# Altium schematic sheet RPI-TPS-GK - CPLD-XC95144.SchDoc
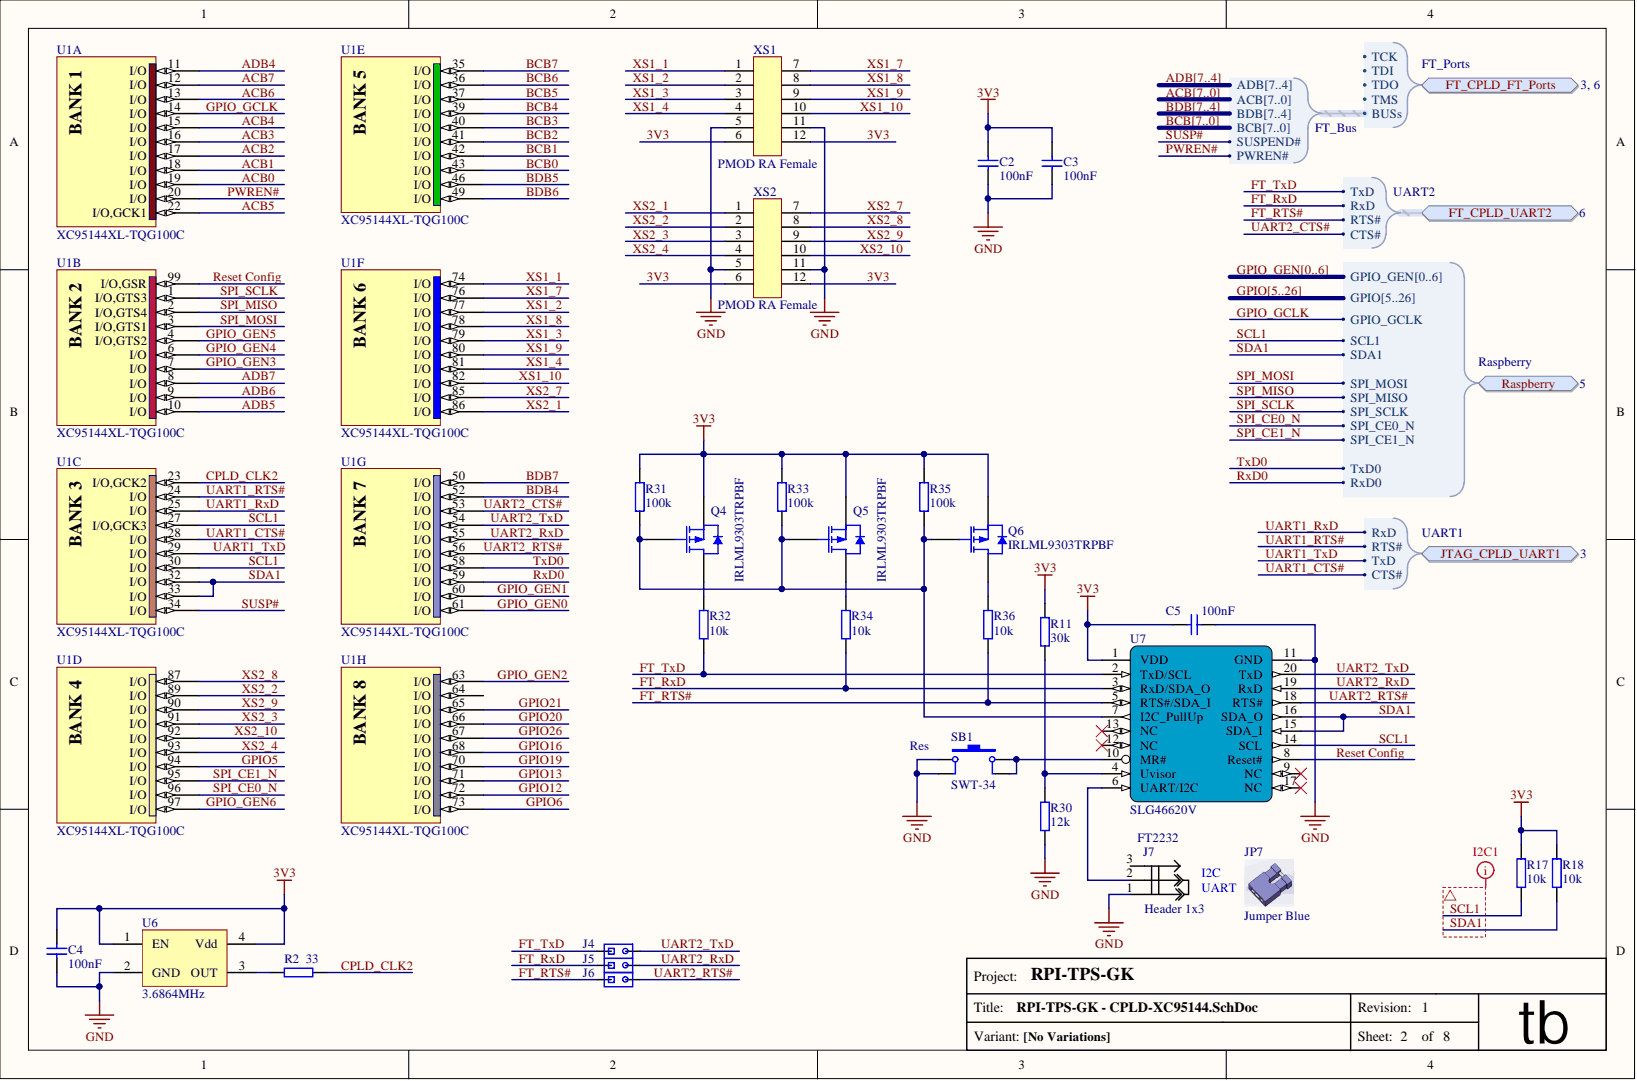
[diagram p0: RPI-TPS-GK - CPLD-XC95144.SchDoc]

## Parts
C2  value 100nF  [C0603]  (hidden comment: CAP)
C3  value 100nF  [C0603]  (hidden comment: CAP)
C4  value 100nF  [C0603]  (hidden comment: CAP)
C5  value 100nF  [C0603]  (hidden comment: CAP)
J4  (no value)  [Jumper_smd_d3]  (no BOM)
J5  (no value)  [Jumper_smd_d3]  (no BOM)
J6  (no value)  [Jumper_smd_d3]  (no BOM)
J7  FT2232  value Header 1x3  [Jumper_3pin]
JP7  value Jumper Blue  Supplier 1 Part: Jumper  (hidden comment: VCC_sel)  (mechanical)
Q4  IRLML9303TRPBF  [SOT-23]
Q5  IRLML9303TRPBF  [SOT-23]
Q6  IRLML9303TRPBF  [SOT-23]
R2  value 33  [0603_RESISTOR]  (hidden comment: Resistor)
R11  value 30k  [0603_RESISTOR]  (hidden comment: Resistor)
R17  value 10k  [0603_RESISTOR]  (hidden comment: Resistor)
R18  value 10k  [0603_RESISTOR]  (hidden comment: Resistor)
R30  value 12k  [0603_RESISTOR]  (hidden comment: Resistor)
R31  value 100k  [0603_RESISTOR]  (hidden comment: Resistor)
R32  value 10k  [0603_RESISTOR]  (hidden comment: Resistor)
R33  value 100k  [0603_RESISTOR]  (hidden comment: Resistor)
R34  value 10k  [0603_RESISTOR]  (hidden comment: Resistor)
R35  value 100k  [0603_RESISTOR]  (hidden comment: Resistor)
R36  value 10k  [0603_RESISTOR]  (hidden comment: Resistor)
SB1  Res  value SWT-34  [Key 6.2x6.2]
U1  value XC95144XL-TQG100C  Supplier 1 Part: XC95144XL-TQG100C  [TQ100 - XC95144XL]  (hidden comment: XC95144XL-SQ100)  (parts A,B,C,D,E,F,G,H)
U6  value 3.6864MHz  Manufacturer Part: 3.6864MHz  [OSC_5x7]  (hidden comment: OSC_Crystal Oscillator)
U7  SLG46620V  [STQFN-20]
XS1  value PMOD RA Female  [CONN_2DG_H_F]  (hidden comment: DG2_connector_horizontal_f)
XS2  value PMOD RA Female  [CONN_2DG_H_F]  (hidden comment: DG2_connector_horizontal_f)

## Ports
FT_CPLD_FT_Ports, FT_CPLD_FT_PORTS.BUSS.ACB0, FT_CPLD_FT_PORTS.BUSS.ACB1, FT_CPLD_FT_PORTS.BUSS.ACB2, FT_CPLD_FT_PORTS.BUSS.ACB3, FT_CPLD_FT_PORTS.BUSS.ACB4, FT_CPLD_FT_PORTS.BUSS.ACB5, FT_CPLD_FT_PORTS.BUSS.ACB6, FT_CPLD_FT_PORTS.BUSS.ACB7, FT_CPLD_FT_Ports.BUSs.ACB[7..0], FT_CPLD_FT_PORTS.BUSS.ADB4, FT_CPLD_FT_PORTS.BUSS.ADB5, FT_CPLD_FT_PORTS.BUSS.ADB6, FT_CPLD_FT_PORTS.BUSS.ADB7, FT_CPLD_FT_Ports.BUSs.ADB[7..4], FT_CPLD_FT_PORTS.BUSS.BCB0, FT_CPLD_FT_PORTS.BUSS.BCB1, FT_CPLD_FT_PORTS.BUSS.BCB2, FT_CPLD_FT_PORTS.BUSS.BCB3, FT_CPLD_FT_PORTS.BUSS.BCB4, FT_CPLD_FT_PORTS.BUSS.BCB5, FT_CPLD_FT_PORTS.BUSS.BCB6, FT_CPLD_FT_PORTS.BUSS.BCB7, FT_CPLD_FT_Ports.BUSs.BCB[7..0], FT_CPLD_FT_PORTS.BUSS.BDB4, FT_CPLD_FT_PORTS.BUSS.BDB5, FT_CPLD_FT_PORTS.BUSS.BDB6, FT_CPLD_FT_PORTS.BUSS.BDB7, FT_CPLD_FT_Ports.BUSs.BDB[7..4], FT_CPLD_FT_Ports.BUSs.PWREN#, FT_CPLD_FT_Ports.BUSs.SUSPEND#, FT_CPLD_FT_Ports.TCK, FT_CPLD_FT_Ports.TDI, FT_CPLD_FT_Ports.TDO, FT_CPLD_FT_Ports.TMS, FT_CPLD_UART2, FT_CPLD_UART2.CTS#, FT_CPLD_UART2.RTS#, FT_CPLD_UART2.RxD, FT_CPLD_UART2.TxD, JTAG_CPLD_UART1, JTAG_CPLD_UART1.CTS#, JTAG_CPLD_UART1.RTS#, JTAG_CPLD_UART1.RxD, JTAG_CPLD_UART1.TxD, Raspberry, RASPBERRY.GPIO5, RASPBERRY.GPIO6, RASPBERRY.GPIO7, RASPBERRY.GPIO8, RASPBERRY.GPIO9, RASPBERRY.GPIO10, RASPBERRY.GPIO11, RASPBERRY.GPIO12, RASPBERRY.GPIO13, RASPBERRY.GPIO14, RASPBERRY.GPIO15, RASPBERRY.GPIO16, RASPBERRY.GPIO17, RASPBERRY.GPIO18, RASPBERRY.GPIO19, RASPBERRY.GPIO20, RASPBERRY.GPIO21, RASPBERRY.GPIO22, RASPBERRY.GPIO23, RASPBERRY.GPIO24, RASPBERRY.GPIO25, RASPBERRY.GPIO26, Raspberry.GPIO[5..26], Raspberry.GPIO_GCLK, RASPBERRY.GPIO_GEN0, RASPBERRY.GPIO_GEN1, RASPBERRY.GPIO_GEN2, RASPBERRY.GPIO_GEN3, RASPBERRY.GPIO_GEN4, RASPBERRY.GPIO_GEN5, RASPBERRY.GPIO_GEN6, Raspberry.GPIO_GEN[0..6], Raspberry.RxD0, Raspberry.SCL1, Raspberry.SDA1, Raspberry.SPI_CE0_N, Raspberry.SPI_CE1_N, Raspberry.SPI_MISO, Raspberry.SPI_MOSI, Raspberry.SPI_SCLK, Raspberry.TxD0

## Nets
GND: C2.1 C3.1 C4.1 C5.1 J7.1 R30.1 SB1.1 SB1.3 U6.2(GND) U7.11(GND) XS1.5 XS1.11 XS2.5 XS2.11
3V3: C2.2 C3.2 C4.2 C5.2 Q4.2 Q5.2 Q6.2 R11.1 R17.2 R18.2 R31.2 R33.2 R35.2 U6.1(EN) U6.4(Vdd) U7.1(VDD) XS1.6 XS1.12 XS2.6 XS2.12
ACB0: U1.19(I/O) ; port FT_CPLD_FT_Ports  [also labelled ACB]
ACB1: U1.18(I/O) ; port FT_CPLD_FT_Ports  [also labelled ACB]
ACB2: U1.17(I/O) ; port FT_CPLD_FT_Ports  [also labelled ACB]
ACB3: U1.16(I/O) ; port FT_CPLD_FT_Ports  [also labelled ACB]
ACB4: U1.15(I/O) ; port FT_CPLD_FT_Ports  [also labelled ACB]
ACB5: U1.22(I/O,GCK1) ; port FT_CPLD_FT_Ports  [also labelled ACB]
ACB6: U1.13(I/O) ; port FT_CPLD_FT_Ports  [also labelled ACB]
ACB7: U1.12(I/O) ; port FT_CPLD_FT_Ports  [also labelled ACB]
ADB4: U1.11(I/O) ; port FT_CPLD_FT_Ports  [also labelled ADB]
ADB5: U1.10(I/O) ; port FT_CPLD_FT_Ports  [also labelled ADB]
ADB6: U1.9(I/O) ; port FT_CPLD_FT_Ports  [also labelled ADB]
ADB7: U1.8(I/O) ; port FT_CPLD_FT_Ports  [also labelled ADB]
BCB0: U1.43(I/O) ; port FT_CPLD_FT_Ports  [also labelled BCB]
BCB1: U1.42(I/O) ; port FT_CPLD_FT_Ports  [also labelled BCB]
BCB2: U1.41(I/O) ; port FT_CPLD_FT_Ports  [also labelled BCB]
BCB3: U1.40(I/O) ; port FT_CPLD_FT_Ports  [also labelled BCB]
BCB4: U1.39(I/O) ; port FT_CPLD_FT_Ports  [also labelled BCB]
BCB5: U1.37(I/O) ; port FT_CPLD_FT_Ports  [also labelled BCB]
BCB6: U1.36(I/O) ; port FT_CPLD_FT_Ports  [also labelled BCB]
BCB7: U1.35(I/O) ; port FT_CPLD_FT_Ports  [also labelled BCB]
BDB4: U1.52(I/O) ; port FT_CPLD_FT_Ports  [also labelled BDB]
BDB5: U1.46(I/O) ; port FT_CPLD_FT_Ports  [also labelled BDB]
BDB6: U1.49(I/O) ; port FT_CPLD_FT_Ports  [also labelled BDB]
BDB7: U1.50(I/O) ; port FT_CPLD_FT_Ports  [also labelled BDB]
CPLD_CLK2: R2.2 U1.23(I/O,GCK2)
FT_RTS#: J6.1 R36.1 U7.5(RTS#/SDA_I) ; port FT_CPLD_UART2
FT_RxD: J5.1 R34.1 U7.3(RxD/SDA_O) ; port FT_CPLD_UART2
FT_TxD: J4.1 R32.1 U7.2(TxD/SCL) ; port FT_CPLD_UART2
GPIO5: U1.94(I/O) ; port Raspberry  [also labelled GPIO]
GPIO6: U1.73(I/O) ; port Raspberry  [also labelled GPIO]
GPIO12: U1.72(I/O) ; port Raspberry  [also labelled GPIO]
GPIO13: U1.71(I/O) ; port Raspberry  [also labelled GPIO]
GPIO16: U1.68(I/O) ; port Raspberry  [also labelled GPIO]
GPIO19: U1.70(I/O) ; port Raspberry  [also labelled GPIO]
GPIO20: U1.66(I/O) ; port Raspberry  [also labelled GPIO]
GPIO21: U1.65(I/O) ; port Raspberry  [also labelled GPIO]
GPIO26: U1.67(I/O) ; port Raspberry  [also labelled GPIO]
GPIO_GCLK: U1.14(I/O) ; port Raspberry
GPIO_GEN0: U1.61(I/O) ; port Raspberry  [also labelled GPIO_GEN]
GPIO_GEN1: U1.60(I/O) ; port Raspberry  [also labelled GPIO_GEN]
GPIO_GEN2: U1.63(I/O) ; port Raspberry  [also labelled GPIO_GEN]
GPIO_GEN3: U1.7(I/O) ; port Raspberry  [also labelled GPIO_GEN]
GPIO_GEN4: U1.6(I/O) ; port Raspberry  [also labelled GPIO_GEN]
GPIO_GEN5: U1.4(I/O,GTS2) ; port Raspberry  [also labelled GPIO_GEN]
GPIO_GEN6: U1.97(I/O) ; port Raspberry  [also labelled GPIO_GEN]
PWREN#: U1.20(I/O) ; port FT_CPLD_FT_Ports
Reset Config: U1.99(I/O,GSR) U7.8(Reset#)
RxD0: U1.59(I/O) ; port Raspberry
SCL1: R17.1 U1.27(I/O,GCK3) U1.30(I/O) U7.14(SCL) ; port Raspberry
SDA1: R18.1 U1.32(I/O) U1.33(I/O) U7.15(SDA_I) U7.16(SDA_O) ; port Raspberry
SPI_CE0_N: U1.96(I/O) ; port Raspberry
SPI_CE1_N: U1.95(I/O) ; port Raspberry
SPI_MISO: U1.2(I/O,GTS4) ; port Raspberry
SPI_MOSI: U1.3(I/O,GTS1) ; port Raspberry
SPI_SCLK: U1.1(I/O,GTS3) ; port Raspberry
SUSP#: U1.34(I/O) ; port FT_CPLD_FT_Ports
TxD0: U1.58(I/O) ; port Raspberry
UART1_CTS#: U1.28(I/O) ; port JTAG_CPLD_UART1
UART1_RTS#: U1.24(I/O) ; port JTAG_CPLD_UART1
UART1_RxD: U1.25(I/O) ; port JTAG_CPLD_UART1
UART1_TxD: U1.29(I/O) ; port JTAG_CPLD_UART1
UART2_CTS#: U1.53(I/O) ; port FT_CPLD_UART2
UART2_RTS#: J6.2 U1.56(I/O) U7.18(RTS#)
UART2_RxD: J5.2 U1.55(I/O) U7.19(RxD)
UART2_TxD: J4.2 U1.54(I/O) U7.20(TxD)
XS1_1: U1.74(I/O) XS1.1
XS1_2: U1.77(I/O) XS1.2
XS1_3: U1.79(I/O) XS1.3
XS1_4: U1.81(I/O) XS1.4
XS1_7: U1.76(I/O) XS1.7
XS1_8: U1.78(I/O) XS1.8
XS1_9: U1.80(I/O) XS1.9
XS1_10: U1.82(I/O) XS1.10
XS2_1: U1.86(I/O) XS2.1
XS2_2: U1.89(I/O) XS2.2
XS2_3: U1.91(I/O) XS2.3
XS2_4: U1.93(I/O) XS2.4
XS2_7: U1.85(I/O) XS2.7
XS2_8: U1.87(I/O) XS2.8
XS2_9: U1.90(I/O) XS2.9
XS2_10: U1.92(I/O) XS2.10
NetJ7_2: J7.2 U7.6(UART/I2C)
NetQ4_1: Q4.1 Q5.1 Q6.1 R31.1 R33.1 R35.1 U7.7(I2C_PullUp)
NetQ4_3: Q4.3 R32.2
NetQ5_3: Q5.3 R34.2
NetQ6_3: Q6.3 R36.2
NetR2_1: R2.1 U6.3(OUT)
NetR11_2: R11.2 R30.2 U7.4(Uvisor)
NetSB1_2: SB1.2 SB1.4 U7.10(MR#)
GPIO7: ; port Raspberry  [also labelled GPIO]
GPIO8: ; port Raspberry  [also labelled GPIO]
GPIO9: ; port Raspberry  [also labelled GPIO]
GPIO10: ; port Raspberry  [also labelled GPIO]
GPIO11: ; port Raspberry  [also labelled GPIO]
GPIO14: ; port Raspberry  [also labelled GPIO]
GPIO15: ; port Raspberry  [also labelled GPIO]
GPIO17: ; port Raspberry  [also labelled GPIO]
GPIO18: ; port Raspberry  [also labelled GPIO]
GPIO22: ; port Raspberry  [also labelled GPIO]
GPIO23: ; port Raspberry  [also labelled GPIO]
GPIO24: ; port Raspberry  [also labelled GPIO]
GPIO25: ; port Raspberry  [also labelled GPIO]
N00008: ; port FT_CPLD_FT_Ports
N00009: ; port FT_CPLD_FT_Ports
N00010:
N00011: ; port FT_CPLD_FT_Ports
N00012: ; port FT_CPLD_FT_Ports

Not connected: J7 3; U1 64(I/O); U7 9(NC) 12(NC) 13(NC) 17(NC)

## Library data of the parts
C2-C5 = CAP: Manufacturer: Vishay / Vitramon; Manufacturer Part: VJ0603Y104JXJPW1BC; Type of Component: Ceramic Capacitor; Component Kind: Standard; Manufacturer Link: http://www.vishay.com/product?docid=28548; Package / Case: 0603; Supplier 1: aliexpress.com; Supplier 1 Link: aliexpress.com/item/100pcs-lot-100nF-X7R-Error-10-50V-0603-smd-capacitor/32318089455.html?spm=2114.03010208.3.2.PDNk2A&ws_ab_test=searchweb201556_8,searchweb201602_1_10065_10068_112_10069_110_111_418_10017_109_108_10060_10061_10062_10057_10039_10056_10055_10054_10059_10058_10073_10070_10052_10053_10050_10051,searchweb201603_1&btsid=c39062ca-3db8-4562-8db0-c782461646c7; Supplier 2: http://imrad.com.ua/; Supplier 2 Link: http://imrad.com.ua/ru/cc0603krx7r8bb104; Supplier 2 Part: 182925; Supplier 3: http://voron.ua; Supplier 3 Link: http://voron.ua/catalog/001832; Supplier 3 Part: 001832; Library Name: RPI-VITA.IntLib
J4-J6 = jumper_2pin_pads: Library Name: RPI-VITA.IntLib
J7 = jumper_3pin: Type of Component: Header for jumper 3 pin; Component Kind: Standard; Package / Case: Header 1 x 3; Supplier 1: aliexpress.com; Supplier 1 Link: aliexpress.com/item/1Set-20PCS-40Pin-2-54mm-Single-Row-Straight-Male-Pin-Header-Connector-Strip-For-PBC-Ardunio/32329088739.html?spm=2114.03010208.3.2.0ijeiM&ws_ab_test=searchweb201556_1,searchweb201644_1_505_506_503_504_502_10001_10002_10017_10010_10005_10011_10006_10003_10004_10009_10007_10008,searchweb201560_5,searchweb1451318400_-1,searchweb1451318411_6452&btsid=d8fe2734-cbe4-429c-a307-e5400297e31b; Supplier 2: http://imrad.com.ua; Supplier 2 Link: http://imrad.com.ua/ru/pls-40-product-122266; Supplier 2 Part: 202826; Supplier 3: http://voron.ua; Supplier 3 Link: http://voron.ua/catalog/000631; Supplier 3 Part: 000631; Library Name: RPI-VITA.IntLib
JP7 = jumper blue: Manufacturer: Great Electric; Manufacturer Part: Jumper Cap Shunts Short Circuit Cap; Package / Case: 2.54mm; Supplier 1: aliexpress.com; Supplier 1 Link: http://www.aliexpress.com/item/500pcs-lot-Asssorted-Color-2-54mm-Standard-Circuit-Board-Jumper-Cap-Shunts-Short-Circuit-Cap-CGKCH119/32344305619.html?spm=2114.03010208.3.80.nPPmoy&ws_ab_test=searchweb201556_1,searchweb201644_1_505_506_503_504_502_10001_10002_10017_10010_10005_10011_10006_10003_10004_10009_10007_10008,searchweb201560_5,searchweb1451318400_-1,searchweb1451318411_6452&btsid=16d11777-edde-4755-b8b5-9adacb495b09; Type of Component: Jumper Blue; Library Name: RPI-VITA.IntLib
Q4-Q6 = p-channel MOSFET: Manufacturer: International Rectifier; Type of Component: Transistor; Component Kind: Standard; Description: p-channel MOSFET; Manufacturer Part: IRLML9303TRPBF; Package / Case: SOT-23; Supplier 2: http://imrad.com.ua; Supplier 2 Link: http://imrad.com.ua/ru/irlml9303trpbf; Supplier 2 Part: 165010; Supplier 3: http://voron.ua; Supplier 3 Link: http://voron.ua/catalog/024279; Supplier 3 Part: 024279; Value: IRLML9303TRPBF; Library Name: RPI-VITA.IntLib
R2 = Resistor: Manufacturer: Vishay / Dale; Manufacturer Part: CRCW060333R0FKEA; Component Kind: Standard; Manufacturer Link: http://www.vishay.com/product?docid=20035; Package / Case: 0603; Supplier 1: aliexpress.com; Supplier 1 Link: aliexpress.com/store/product/0603-SMD-Resistor-1-33-ohm-5000pcs-lot/506373_32308168229.html?detailNewVersion=&categoryId=400503&spm=2114.12010208.1000023.114.SHnexu; Supplier 1 Part: 0603 33; Supplier 2: http://imrad.com.ua; Supplier 2 Link: http://imrad.com.ua/ru/rc0603fr-0733rl; Supplier 2 Part: 183826; Supplier 3: http://voron.ua; Supplier 3 Link: http://voron.ua/catalog/023629; Supplier 3 Part: 023629; Type of Component: Resistor; Library Name: RPI-VITA.IntLib
R17 R18 R32 R34 R36 = Resistor: Manufacturer: Vishay / Dale; Manufacturer Part: CRCW060310K0FKEA; Component Kind: Standard; Manufacturer Link: http://www.vishay.com/product?docid=20035; Package / Case: 0603; Supplier 1: aliexpress.com; Supplier 1 Link: aliexpress.com/store/product/0603-SMD-Resistor-5-10K-ohm-5000pcs-lot/506373_32307351301.html?storeId=506373&spm=2114.12010208.100007.103.XAQnpm; Supplier 1 Part: 0603 10k; Supplier 2: http://imrad.com.ua/; Supplier 2 Link: http://imrad.com.ua/ru/rc0603jr-0710kl; Supplier 2 Part: 183440; Supplier 3: http://www.kosmodrom.com.ua; Supplier 3 Link: http://www.kosmodrom.com.ua/prodlist.php?page=0&name=%280603%29+10+kom&okbutton=%CF%EE%E8%F1%EA+%EF%EE+%F1%EA%EB%E0%E4%F3; Supplier 3 Part: (0603) 10 kom; Type of Component: Resistor; Library Name: RPI-VITA.IntLib
R30 = Resistor: Manufacturer: Vishay / Dale; Manufacturer Part: CRCW060312K0FKEA; Component Kind: Standard; Manufacturer Link: http://www.vishay.com/product?docid=20035; Package / Case: 0603; Supplier 1: aliexpress.com; Supplier 1 Link: aliexpress.com/item/Free-Shipping-100PCS-0603-12K-12K-OHM-5-smd-resistor/1871918358.html?spm=2114.01010208.3.43.AuqUxr&ws_ab_test=searchweb0_0,searchweb201602_1_10065_10068_10501_10503_10000032_119_10000025_10000029_430_10000028_10060_10062_10056_10055_10000062_10054_10059_10099_10000022_10000012_10103_10000015_10102_10096_10000018_10000019_10000056_10000059_10052_10053_10107_10050_10106_10051_10000053_10000007_10000050_10084_10118_10083_10000047_10080_10082_10081_10110_10111_10112_10113_10114_10115_10000041_10000044_10078_10079_10000038_429_10073_10000035_10121-10503_10501,searchweb201603_1,afswitch_1,single_sort_1_default&btsid=5caa854b-9869-4055-9d65-88f7445dd534; Supplier 1 Part: 0603 12k; Supplier 2: http://imrad.com.ua/; Supplier 2 Link: http://imrad.com.ua/ru/rc0603jr-0712kl; Supplier 2 Part: 194449; Supplier 3: http://voron.ua/; Supplier 3 Link: http://voron.ua/catalog/023603; Supplier 3 Part: 023603; Type of Component: Resistor; Library Name: RPI-VITA.IntLib
SB1 = SB_Tactile: Tactile Switches; Type of Component: Tact Switch; Component Kind: Standard; Package / Case: 6.2 x 6.2 x 5; Supplier 1: aliexpress.com; Supplier 1 Link: aliexpress.com/item/50-Pcs-Momentary-Tact-Tactile-Switch-SMD-SMT-PCB-4-Pin-6-x-6-x/32606731512.html?spm=2114.03010208.3.152.FgYqgH&ws_ab_test=searchweb0_0,searchweb201602_1_10065_10068_10501_10503_10000032_119_10000025_10000029_430_10000028_10060_10062_10056_10055_10000062_10054_10059_10099_10000022_10000012_10103_10000015_10102_10096_10000018_10000019_10000056_10000059_10052_10053_10107_10050_10106_10051_10000053_10000007_10000050_10117_10084_10083_10118_10000047_10080_10082_10081_10110_10111_10112_10113_10114_10115_10000041_10000044_10078_10079_10000038_429_10073_10000035_10121-10503_10501,searchweb201603_1,afswitch_1,single_sort_1_default&btsid=da13b4f2-05a9-443d-b1b0-b3b976e778d9; Supplier 2: http://imrad.com.ua/; Supplier 2 Link: http://imrad.com.ua/ru/swt-34-h5mm; Supplier 2 Part: 41517; Supplier 3: http://voron.ua/; Supplier 3 Link: http://voron.ua/catalog/017636; Supplier 3 Part: 017636; Library Name: RPI-VITA.IntLib
U1 = XC95144XL-SQ100: The XC95144XL is a 3.3V CPLD targeted for high-performance,  low-voltage applications in leading-edge communications  and computing systems.; Manufacturer: Xilinx Inc; Manufacturer Part: XC95144XL-10TQG100C; Component Kind: Standard; Manufacturer Link: http://xgoogle.xilinx.com/search?output=xml_no_dtd&ie=UTF-8&oe=UTF-8&client=xilinx&proxystylesheet=xilinx&site=EntireSite&filter=0&num=200&sort=date:D:L:d1&getfields=*&show_dynamic_navigation=1&requiredfields=-Archived%3Atrue&q=XC95144XL-10TQG100C&as_q=; Package / Case: TQG-100C; Supplier 1: HK-Ada; Type of Component: CPLD; Library Name: RPI-VITA.IntLib
U6 = OSC_Crystal Oscillator: Manufacturer: KKQ; Type of Component: Clock Oscillator; Component Kind: Standard; Package / Case: 5 mm x 7 mm; Supplier 1: aliexpress.com; Supplier 1 Link: http://aliexpress.com/item/100PCS-Original-NEW-3-6864MHZ-3-6864M-3-6864-5-7-4P-5070-OSC-5-00mm/32275012196.html?detailNewVersion=1; Supplier 1 Part: 3.6864MHz 4 pin; Library Name: RPI-VITA.IntLib
U7 = SLG46620: GreenPAK Programmable Mixed Signal Array; Manufacturer: Silego; Type of Component: GPAK-4; Component Kind: Standard; Manufacturer Link: http://www.silego.com/products/greenpak4.html; Manufacturer Part: SLG46620V; Package / Case: STQFN-20; Supplier 1: silego.com; Supplier 1 Link: http://www.silego.com/buy/index.php?main_page=product_info&cPath=67&products_id=379; Supplier 1 Part: SLG46620V; Value: SLG46620V; Library Name: RPI-VITA.IntLib
XS1 XS2 = DG2_connector_horizontal_f: SSHR-1-06-D; Manufacturer: HK-Ada; Manufacturer Part: PMOD 2x6 RA F; Type of Component: PMOD Right Angle Female; Component Kind: Standard; Package / Case: Header 2x6 RA; Supplier 1: aliexpress.com; Supplier 1 Link: aliexpress.com/item/100pcs-lot-2-54MM-2-6-P-2-54mm-2X6-Female-Double-Cab-Looper-Right-Angle/32674987997.html?spm=2114.03010208.3.2.JhMAJ9&ws_ab_test=searchweb0_0,searchweb201602_1_116_10065_117_10068_114_115_10069_113_10017_10080_10082_10081_10060_10061_10062_10039_10056_10055_10054_10059_10078_10079_10073_10070_421_420_10052_10053_10050_10051,searchweb201603_1&btsid=bf0396e8-448a-4b04-ba35-cc9eaa25fb0f; Supplier 1 Part: Header 2x6; Supplier 2: voron.ua; Supplier 2 Link: http://voron.ua/catalog/019905; Supplier 2 Part: 019905; Library Name: RPI-VITA.IntLib
R11 R31 R33 R35 = Resistor: Component Kind: Standard; Package / Case: 0603; Supplier 1: aliexpress.com; Type of Component: Resistor; Library Name: RPI-VITA.IntLib
  R11: Supplier 1 Link: aliexpress.com/store/product/0603-SMD-Resistor-5-30K-ohm-5000pcs-lot/506373_32307002787.html?detailNewVersion=&categoryId=400503&spm=2114.12010208.1000023.136.sR3Wdj; Supplier 1 Part: 0603 33; Supplier 2: http://imrad.com.ua; Supplier 2 Link: http://imrad.com.ua; Supplier 2 Part: http://imrad.com.ua/ru/rc0603fr-0730kl
  R31 R33 R35: Supplier 1 Link: aliexpress.com/store/product/0603-SMD-Resistor-5-100K-ohm-5000pcs-lot/506373_32307371012.html?detailNewVersion=&categoryId=400503&spm=2114.12010208.1000023.149.s8YZls; Supplier 1 Part: 0603 100k; Supplier 2: http://imrad.com.ua/; Supplier 2 Link: http://imrad.com.ua/ru/rc0603fr-07100kl; Supplier 2 Part: 182514; Supplier 3: http://voron.ua; Supplier 3 Link: http://voron.ua/catalog/002199; Supplier 3 Part: 002199
